# Altium schematic sheet 200_SOC.SchDoc
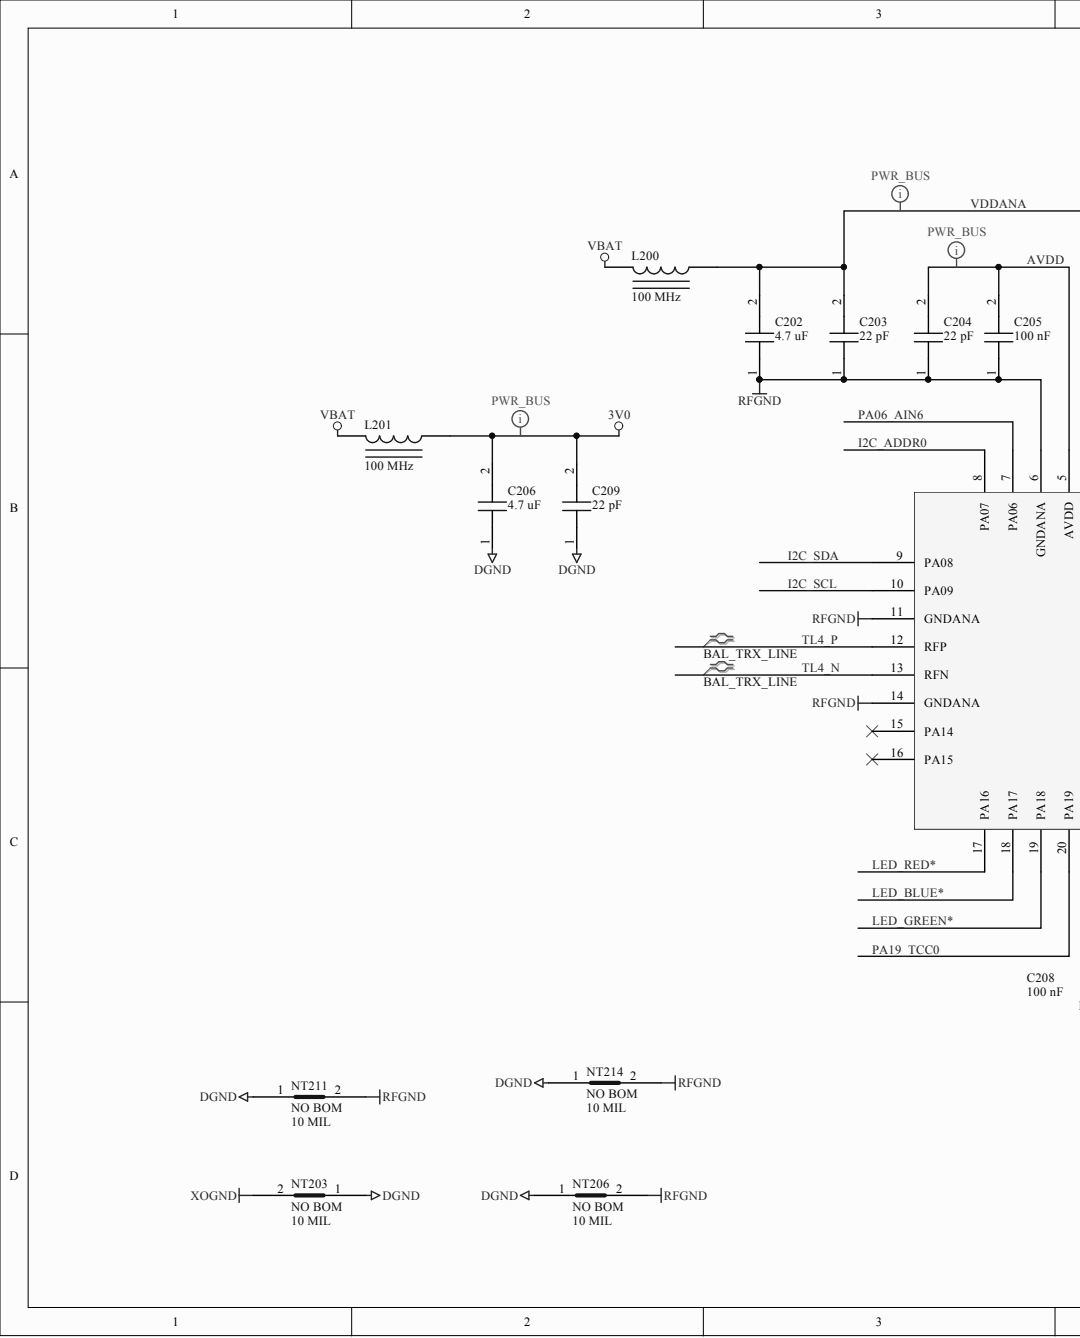
[diagram p0: 200_SOC.SchDoc, left]
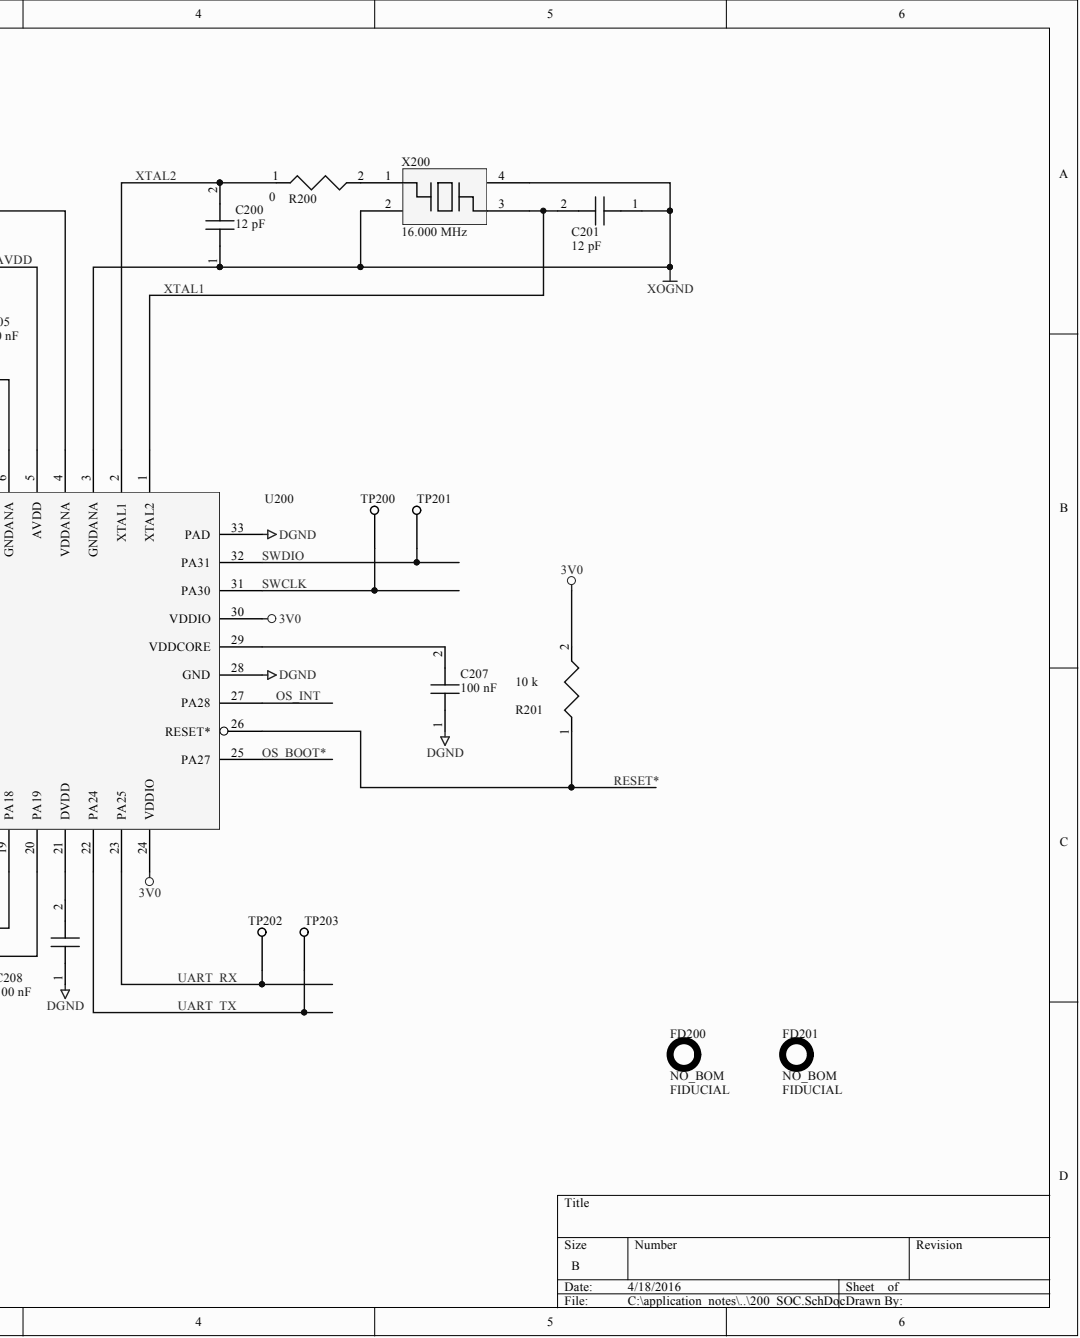
[diagram p1: 200_SOC.SchDoc, right]

## Parts
C200  12 pF  [CAPC1005N]
C201  12 pF  [CAPC1005N]
C202  4.7 uF  [CAPC1608N]
C203  22 pF  [CAPC1005N]
C204  22 pF  [CAPC1005N]
C205  100 nF  [CAPC1005N]
C206  4.7 uF  [CAPC1608N]
C207  100 nF  [CAPC1005N]
C208  100 nF  [CAPC1005N]
C209  22 pF  [CAPC1005N]
FD200  NO_BOM  value FIDUCIAL  [FIDUCIAL]  (no BOM)
FD201  NO_BOM  value FIDUCIAL  [FIDUCIAL]  (no BOM)
L200  value 100 MHz  [INDC1608AN]  (hidden comment: Inductor_Iron)
L201  value 100 MHz  [INDC1608AN]  (hidden comment: Inductor_Iron)
NT203  NO BOM  value 10 MIL  [NET_TIE_10_MIL]
NT206  NO BOM  value 10 MIL  [NET_TIE_10_MIL]
NT211  NO BOM  value 10 MIL  [NET_TIE_10_MIL]
NT214  NO BOM  value 10 MIL  [NET_TIE_10_MIL]
R200  0  [RESC1005N]
R201  10 k  [RESC1005N]
TP200  TEST_POINT  [TEST_POINT]  (value not drawn)  (no BOM)
TP201  TEST_POINT  [TEST_POINT]  (value not drawn)  (no BOM)
TP202  TEST_POINT  [TEST_POINT]  (value not drawn)  (no BOM)
TP203  TEST_POINT  [TEST_POINT]  (value not drawn)  (no BOM)
U200  ATSAMR21E18A-MU  [QFN50P500X500-32W4L]  (value not drawn)
X200  16.000 MHz  [XO_4SMD]

## Nets
3V0: C206.2 C209.2 L201.1 R201.2 U200.24(VDDIO) U200.30(VDDIO)
DGND: C206.1 C207.1 C208.1 C209.1 NT203.1 NT206.1 NT211.1 NT214.1 U200.28(GND) U200.33(PAD)
RFGND: C202.1 C203.1 C204.1 C205.1 NT206.2 NT211.2 NT214.2 U200.6(GNDANA) U200.11(GNDANA) U200.14(GNDANA)
VBAT: L200.2 L201.2
XOGND: C200.1 C201.1 NT203.2 U200.3(GNDANA) X200.2 X200.4
AVDD: C204.2 C205.2 U200.5(AVDD)
I2C_ADDR0: U200.8(PA07)
I2C_SCL: U200.10(PA09)
I2C_SDA: U200.9(PA08)
LED_BLUE*: U200.18(PA17)
LED_GREEN*: U200.19(PA18)
LED_RED*: U200.17(PA16)
OS_BOOT*: U200.25(PA27)
OS_INT: U200.27(PA28)
PA06_AIN6: U200.7(PA06)
PA19_TCC0: U200.20(PA19)
RESET*: R201.1 U200.26(RESET*)
SWCLK: TP200.1 U200.31(PA30)
SWDIO: TP201.1 U200.32(PA31)
TL4_N: U200.13(RFN)
TL4_P: U200.12(RFP)
UART_RX: TP202.1 U200.23(PA25)
UART_TX: TP203.1 U200.22(PA24)
VDDANA: C202.2 C203.2 L200.1 U200.4(VDDANA)
XTAL1: C201.2 U200.1(XTAL2) X200.3
XTAL2: C200.2 R200.1 U200.2(XTAL1)
NetC207_2: C207.2 U200.29(VDDCORE)
NetC208_2: C208.2 U200.21(DVDD)
NetR200_2: R200.2 X200.1

Not connected: U200 15(PA14) 16(PA15)

## Library data of the parts
C200 C201 = C_0402: CAP CER 12PF 50V 1% NP0 0402; MPN: GRM1555C1H120FA01D; MFG: MURATA; VENDOR: DIGIKEY; VPN: 490-6196-1-ND; Component Kind: Standard; Library Name: rlc.SchLib; VALUE: 12 pF
C202 C206 = C_0603: CAP CER 4.7UF 6.3V 10% X5R 0603; MPN: GRM188R60J475KE19D; MFG: MURATA; VENDOR: DIGIKEY; VPN: 490-3297-1-ND; Component Kind: Standard; Library Name: rlc.SchLib; VALUE: 4.7 uF
C203 C204 C209 = C_0402: CAP CER 22PF 50V 1% NP0 0402; MPN: GRM1555C1H220FA01D; MFG: MURATA; VENDOR: DIGIKEY; VPN: 490-8589-1-ND; Component Kind: Standard; Library Name: rlc.SchLib; VALUE: 22 pF
C205 C207 C208 = C_0402: CAP CER 0.1UF 10V 10% X5R 0402; MPN: GRM155R61A104KA01D; MFG: MURATA; VENDOR: DIGIKEY; VPN: 490-1318-1-ND; Component Kind: Standard; Library Name: rlc.SchLib; VALUE: 100 nF
FD200 FD201 = FIDUCIAL: Location Point; Library Name: fiddly_bits.SchLib
L200 L201 = A03-0072: FERRITE BEAD 220 OHM .5A 0603; MPN: 74279263; Database Table Name: AltiumComponents; MFG: Würth Elektronik; Vendor: Digikey; VPN: 732-1581-1-ND; Component Kind: Standard; Library Name: AltiumDbLib.DbLib
NT203 NT206 NT211 NT214 = NET_TIE_10_MIL: NET TIE shorting strap; ClassName: NET_TIE; Component Kind: Net Tie; Library Name: net_tie.SchLib
R200 R201 = R_0402: R 0402; MFG: GENERIC; Component Kind: Standard; Library Name: rlc.SchLib
  R200: VALUE: 0
  R201: VALUE: 10 k
TP200-TP203 = TEST_POINT: Test Point 40 mil dia; Library Name: fiddly_bits.SchLib
U200 = SAM_R21E-QFN32: IC MCU 32BIT 256KB FLASH 32QFN; MFG: ATMEL; Value: IEEE 802.15.4; Vendor: Digikey; VPN: ATSAMR21E18A-MU-ND; ClassName: NEEDS_NET_TIE; Component Kind: Standard; Library Name: atsamr21.SchLib; MPN: ATSAMR21E18A-MU
X200 = X_4SMD: 16MHz ±10ppm Crystal 9pF 54 Ohm -40°C ~ 85°C Surface Mount 4-SMD, No Lead (DFN, LCC); MPN: CX3225SB16000E0FPZ25; MFG: AVX; VENDOR: DIGIKEY; VPN: 478-4815-1-ND; Component Kind: Standard; Library Name: crystals.SchLib; VALUE: 16.000 MHz
